# Altium schematic sheet sd_card.SchDoc
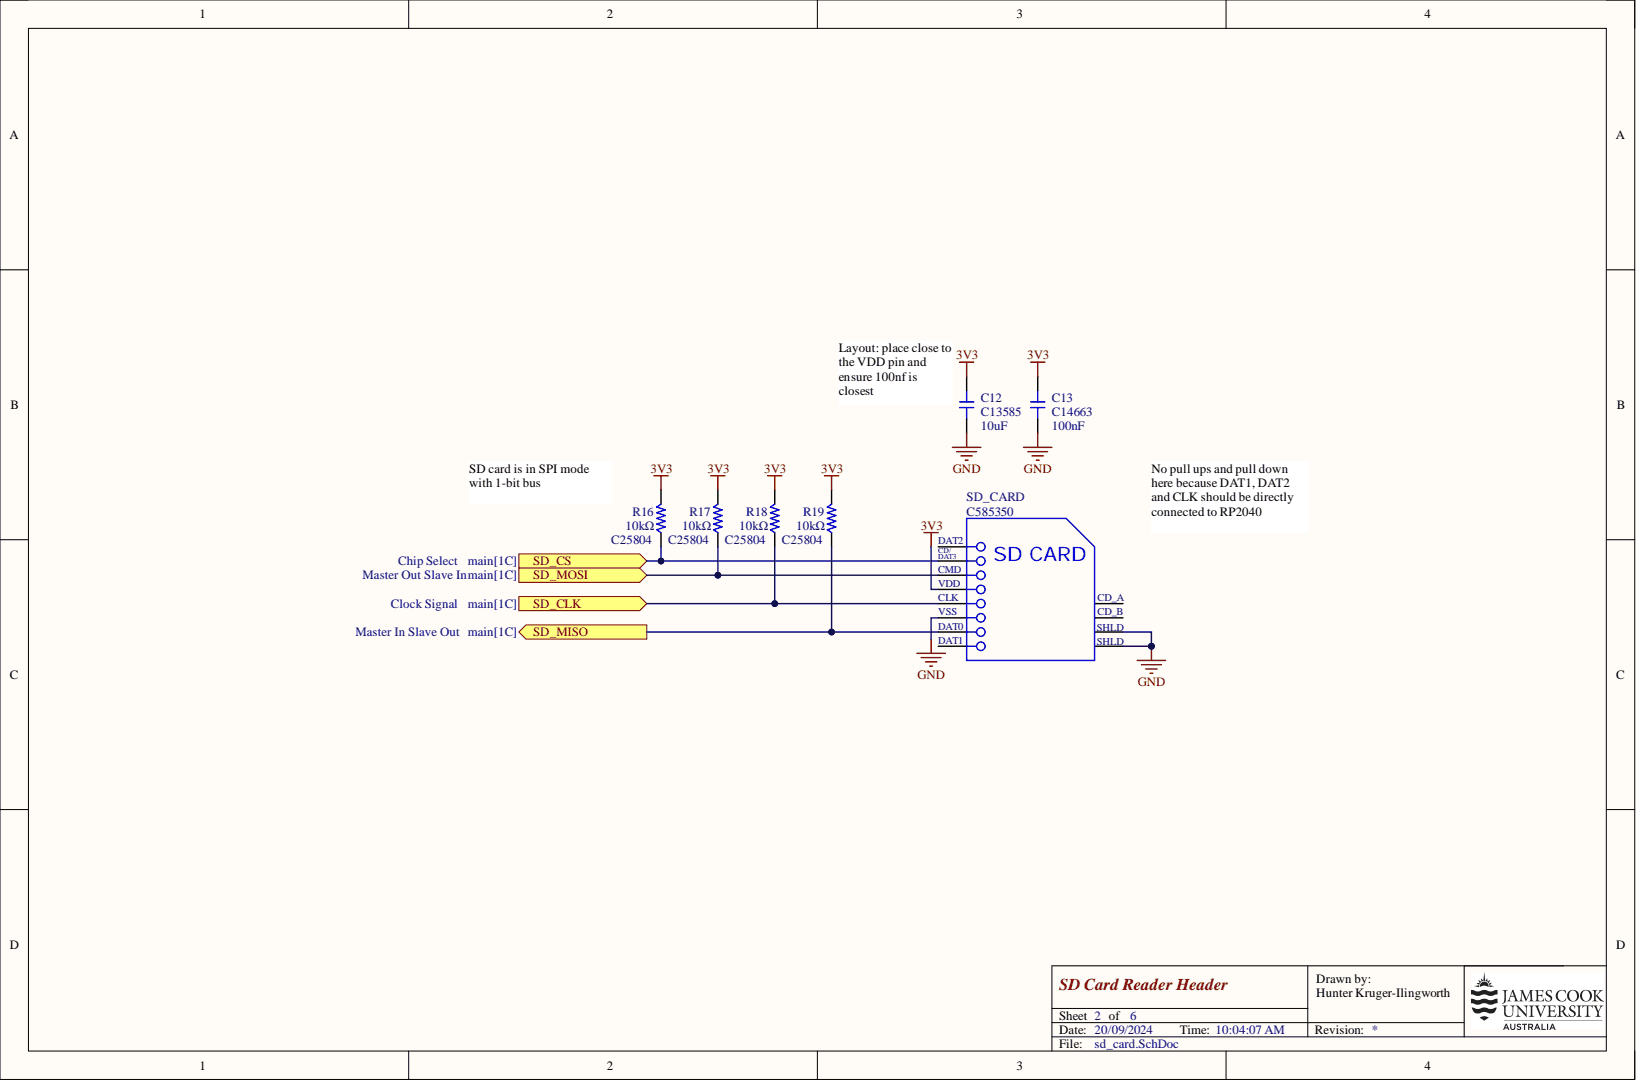
[diagram p0: sd_card.SchDoc]

## Parts
C12  Capacitance: 10uF  JLCPCB Part: C13585  [CAP-1206]  (hidden comment: CL31A106KBHNNNE)
C13  Capacitance: 100nF  JLCPCB Part: C14663  [CAP-0603]  (hidden comment: CC0603KRX7R9BB104)
R16  JLCPCB Part: C25804  Resistance: 10kΩ  [RES-0603]  (hidden comment: 0603WAF1002T5E)
R17  JLCPCB Part: C25804  Resistance: 10kΩ  [RES-0603]  (hidden comment: 0603WAF1002T5E)
R18  JLCPCB Part: C25804  Resistance: 10kΩ  [RES-0603]  (hidden comment: 0603WAF1002T5E)
R19  JLCPCB Part: C25804  Resistance: 10kΩ  [RES-0603]  (hidden comment: 0603WAF1002T5E)
SD_CARD  JLCPCB Part: C585350  [MOLX-104031-0811_V]  (hidden comment: 104031-0811)

## Ports
SD_CLK, SD_CS, SD_MISO, SD_MOSI

## Nets
3V3: C12.2 C13.2 R16.2 R17.2 R18.2 R19.2 SD_CARD.4
GND: C12.1 C13.1 SD_CARD.6 SD_CARD.11 SD_CARD.12
SD_CLK: R18.1 SD_CARD.5 ; port SD_CLK
SD_CS: R16.1 SD_CARD.2(SD_CARD) ; port SD_CS
SD_MISO: R19.1 SD_CARD.7 ; port SD_MISO
SD_MOSI: R17.1 SD_CARD.3 ; port SD_MOSI
NetSD_CARD_1: SD_CARD.1
NetSD_CARD_8: SD_CARD.8
NetSD_CARD_9: SD_CARD.9
NetSD_CARD_10: SD_CARD.10

## Library data of the parts
C12 = CMP-001-00157-1: Capacitor, Ceramic (MLCC), 10uF, ±10%, 50V, X5R, ±10%, SMD 1206; Manufacturer: Samsung Electro-Mechanics; Category: Capacitors - MLCC; Component Kind: Standard; ComponentLink1Description: Datasheet; ComponentLink1URL: https://datasheet.lcsc.com/lcsc/1810221112_Samsung-Electro-Mechanics-CL31A106KBHNNNE_C13585.pdf; ComponentLink2Description: JLCPCB Part URL; ComponentLink2URL: https://jlcpcb.com/partdetail/C13585; ComponentLink3Description: LCSC Part URL; ComponentLink3URL: https://lcsc.com/product-detail/Multilayer-Ceramic-Capacitors-MLCC---SMD-SMT_Samsung-Electro-Mechanics-Samsung-Electro-Mechanics-CL31A106KBHNNNE_C13585.html; Design Item ID: CL31A106KBHNNNE; JLCPCB Basic Part: Yes; JLCPCB Part Number: C13585; Manufacturer Part Number: CL31A106KBHNNNE; Package Size: 1206; RoHS: Yes; Source: jlcpcb_capacitors_basic.schlib; Temperature Coefficient: X5R; Tolerance: ±10%; Voltage Rating: 50V; Library Name: James Cook University
C13 = CMP-001-00167-1: Capacitor, Ceramic (MLCC), 100nF, ±10%, 50V, X7R, ±10%, SMD 0603; Manufacturer: YAGEO; Category: Capacitors - MLCC; Component Kind: Standard; ComponentLink1Description: Datasheet; ComponentLink1URL: https://datasheet.lcsc.com/lcsc/1809301912_YAGEO-CC0603KRX7R9BB104_C14663.pdf; ComponentLink2Description: JLCPCB Part URL; ComponentLink2URL: https://jlcpcb.com/partdetail/C14663; ComponentLink3Description: LCSC Part URL; ComponentLink3URL: https://lcsc.com/product-detail/Multilayer-Ceramic-Capacitors-MLCC---SMD-SMT_YAGEO-YAGEO-CC0603KRX7R9BB104_C14663.html; Design Item ID: CC0603KRX7R9BB104; JLCPCB Basic Part: Yes; JLCPCB Part Number: C14663; Manufacturer Part Number: CC0603KRX7R9BB104; Package Size: 0603; RoHS: Yes; Source: jlcpcb_capacitors_basic.schlib; Temperature Coefficient: X7R; Tolerance: ±10%; Voltage Rating: 50V; Library Name: James Cook University
R16-R19 = CMP-009-00072-1: Resistor, 10kΩ, ±1%, 100mW, 75V, ±100ppm/℃, -55℃~+155℃, SMD 0603; Manufacturer: Uniroyal; Category: Resistors; Component Kind: Standard; ComponentLink1Description: Datasheet; ComponentLink1URL: https://datasheet.lcsc.com/lcsc/2206010045_UNI-ROYAL-Uniroyal-Elec-0603WAF1002T5E_C25804.pdf; ComponentLink2Description: JLCPCB Part URL; ComponentLink2URL: https://jlcpcb.com/partdetail/C25804; Design Item ID: 0603WAF1002T5E; JLCPCB Basic Part: Yes; Manufacturer Part Number: 0603WAF1002T5E; Package Size: 0603; Power Rating: 100mW; Source: jlcpcb_resistors_basic.schlib; Temperature Coefficient: ±100ppm/℃; Temperature Rating: -55℃~+155℃; Tolerance: ±1%; Voltage Rating: 75V; Library Name: James Cook University
SD_CARD = CMP-2000-05825-1: Micro SD Card, RA, -25 to 85 degC, 8-Pin SMD, RoHS, Tape and Reel; Brand: Molex; Current Rating: 500mA; Insertion - Extraction Style: Push-Pull; Mating Cycles: 10000; Number of Contacts: 8; Pitch: 1.1mm; Voltage Rating: 10VAC/DC; Card Type: microSD; Component Kind: Standard; Contact Material: PhosphorBronze; Contact Plating: Gold; Datasheet URL: http://www.molex.com/pdm_docs/sd/1040310811_sd.pdf; Datasheet Version: Rev. E, 04/2006; Height: 1.42mm; Housing Material: LiquidCrystalPolymer(LCP); Length: 11.4mm; Library Name: Altium Content Vault; Manufacturer: Molex; Manufacturer URL: http://www.molex.com/; Mounting Technology: SurfaceMount; Mounting Type: Standard; Number of Rows: 1Row; Orientation: RightAngle; Package Description: 8-Pin Surface Mount Device, Body 11.95 x 11.4 mm, Pitch 1.1 mm; Package Reference: 104031-0811; Packaging: Reel; Product: CardConnectors; Product Category: MemoryCardConnectors; RoHS: True; Series: 104031; Termination Style: SMD
